# Altium schematic sheet stm32.SchDoc
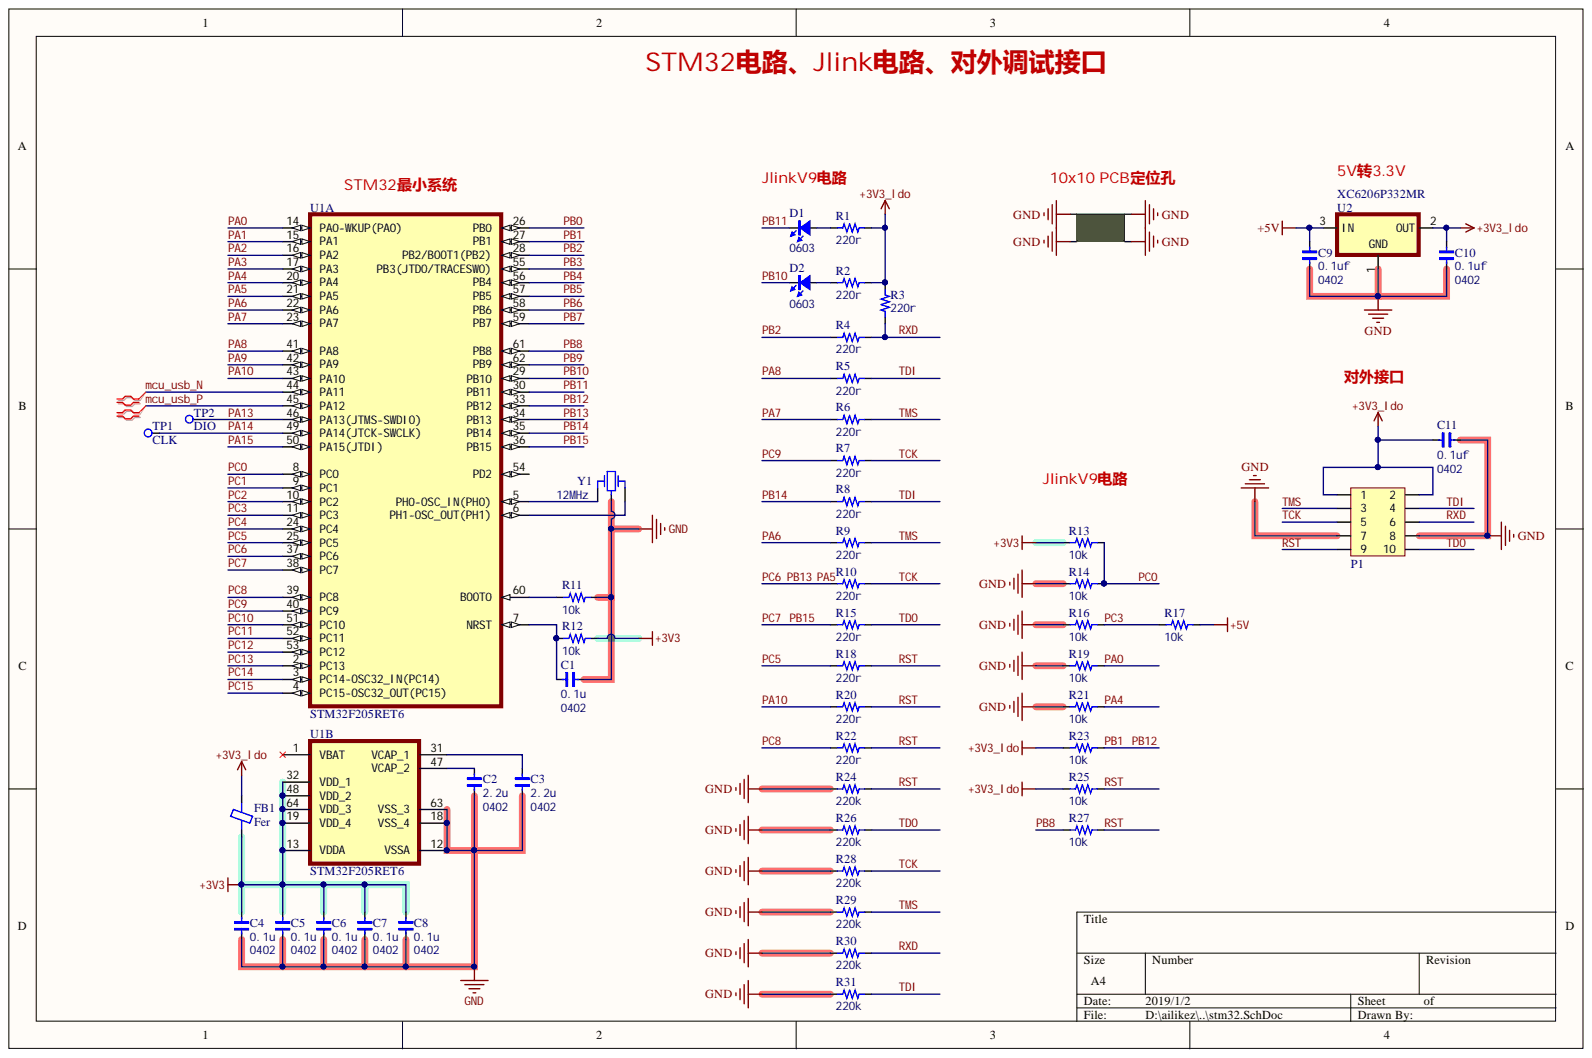
[diagram p0: stm32.SchDoc]

## Parts
C1  value 0.1u  Type: 0402  [CAP_0402]  (hidden comment: C0402)
C2  value 2.2u  Type: 0402  [CAP_0402]  (hidden comment: C0402)
C3  value 2.2u  Type: 0402  [CAP_0402]  (hidden comment: C0402)
C4  value 0.1u  Type: 0402  [CAP_0402]  (hidden comment: C0402)
C5  value 0.1u  Type: 0402  [CAP_0402]  (hidden comment: C0402)
C6  value 0.1u  Type: 0402  [CAP_0402]  (hidden comment: C0402)
C7  value 0.1u  Type: 0402  [CAP_0402]  (hidden comment: C0402)
C8  value 0.1u  Type: 0402  [CAP_0402]  (hidden comment: C0402)
C9  value 0.1uf  Type: 0402  [CAP_0402]  (hidden comment: C0402)
C10  value 0.1uf  Type: 0402  [CAP_0402]  (hidden comment: C0402)
C11  value 0.1uf  Type: 0402  [CAP_0402]  (hidden comment: C0402)
D1  Type: 0603  [LED_0603]  (hidden comment: color?)
D2  Type: 0603  [LED_0603]  (hidden comment: color?)
FB1  Fer  [FER 0603/1608]
P1  HDR2x5_2.54  [HDR2X5_2.54_180H]  (value not drawn)
PCB  PCB_100x100  [PCB_100x100]  (value not drawn)
R1  220r  [RES_0402]
R2  220r  [RES_0402]
R3  220r  [RES_0402]
R4  220r  [RES_0402]
R5  220r  [RES_0402]
R6  220r  [RES_0402]
R7  220r  [RES_0402]
R8  220r  [RES_0402]
R9  220r  [RES_0402]
R10  220r  [RES_0402]
R11  10k  [RES_0402]
R12  10k  [RES_0402]
R13  10k  [RES_0402]
R14  10k  [RES_0402]
R15  220r  [RES_0402]
R16  10k  [RES_0402]
R17  10k  [RES_0402]
R18  220r  [RES_0402]
R19  10k  [RES_0402]
R20  220r  [RES_0402]
R21  10k  [RES_0402]
R22  220r  [RES_0402]
R23  10k  [RES_0402]
R24  220k  [RES_0402]
R25  10k  [RES_0402]
R26  220k  [RES_0402]
R27  10k  [RES_0402]
R28  220k  [RES_0402]
R29  220k  [RES_0402]
R30  220k  [RES_0402]
R31  220k  [RES_0402]
TP1  CLK  [TP Circle]
TP2  DIO  [TP Circle]
U1  STM32F205RET6  [_LQFP_P0.5_64]  (parts A,B)
U2  XC6206P332MR  [_SOT23-3]
Y1  12MHz  [MURATA CSTCE]

## Nets
+3V3: C4.2 C5.2 C6.2 C7.2 C8.2 FB1.1 R12.2 R13.1 U1.13(VDDA) U1.19(VDD_4) U1.32(VDD_1) U1.48(VDD_2) U1.64(VDD_3)
+3V3_ldo: C10.2 C11.2 FB1.2 P1.1 P1.2 R1.2 R2.2 R3.2 R23.1 R25.1 U2.2
+5V: C9.2 R17.2 U2.3
GND: C1.2 C2.1 C3.1 C4.1 C5.1 C6.1 C7.1 C8.1 C9.1 C10.1 C11.1 P1.7 P1.8 PCB.1 PCB.2 PCB.3 PCB.4 R11.2 R14.1 R16.1 R19.1 R21.1 R24.1 R26.1 R28.1 R29.1 R30.1 R31.1 U1.12(VSSA) U1.18(VSS_4) U1.63(VSS_3) U2.1 Y1.2
mcu_usb_N: U1.44(PA11)
mcu_usb_P: U1.45(PA12)
PA0: R19.2 U1.14(PA0-WKUP(PA0))
PA1: U1.15(PA1)
PA2: U1.16(PA2)
PA3: U1.17(PA3)
PA4: R21.2 U1.20(PA4)
PA5: R10.1 U1.21(PA5) U1.34(PB13) U1.37(PC6)
PA6: R9.1 U1.22(PA6)
PA7: R6.1 U1.23(PA7)
PA8: R5.1 U1.41(PA8)
PA9: U1.42(PA9)
PA10: R20.1 U1.43(PA10)
PA13: TP2.1 U1.46(PA13(JTMS-SWDIO))
PA14: TP1.1 U1.49(PA14(JTCK-SWCLK))
PA15: U1.50(PA15(JTDI))
PB0: U1.26(PB0)
PB1: R23.2 U1.27(PB1) U1.33(PB12)
PB2: R4.1 U1.28(PB2/BOOT1(PB2))
PB3: U1.55(PB3(JTDO/TRACESWO))
PB4: U1.56(PB4)
PB5: U1.57(PB5)
PB6: U1.58(PB6)
PB7: U1.59(PB7)
PB8: R27.1 U1.61(PB8)
PB9: U1.62(PB9)
PB10: D2.2 U1.29(PB10)
PB11: D1.2 U1.30(PB11)
PB14: R8.1 U1.35(PB14)
PB15: R15.1 U1.36(PB15) U1.38(PC7)
PC0: R13.2 R14.2 U1.8(PC0)
PC1: U1.9(PC1)
PC2: U1.10(PC2)
PC3: R16.2 R17.1 U1.11(PC3)
PC4: U1.24(PC4)
PC5: R18.1 U1.25(PC5)
PC8: R22.1 U1.39(PC8)
PC9: R7.1 U1.40(PC9)
PC10: U1.51(PC10)
PC11: U1.52(PC11)
PC12: U1.53(PC12)
PC13: U1.2(PC13)
PC14: U1.3(PC14-OSC32_IN(PC14))
PC15: U1.4(PC15-OSC32_OUT(PC15))
RST: P1.9 R18.2 R20.2 R22.2 R24.2 R25.2 R27.2
RXD: P1.6 R3.1 R4.2 R30.2
TCK: P1.5 R7.2 R10.2 R28.2
TDI: P1.4 R5.2 R8.2 R31.2
TDO: P1.10 R15.2 R26.2
TMS: P1.3 R6.2 R9.2 R29.2
NetC1_1: C1.1 R12.1 U1.7(NRST)
NetC2_2: C2.2 U1.47(VCAP_2)
NetC3_2: C3.2 U1.31(VCAP_1)
NetD1_1: D1.1 R1.1
NetD2_1: D2.1 R2.1
NetR11_1: R11.1 U1.60(BOOT0)
NetU1_5: U1.5(PH0-OSC_IN(PH0)) Y1.1
NetU1_6: U1.6(PH1-OSC_OUT(PH1)) Y1.3

Not connected: U1 1(VBAT) 54(PD2)

## Library data of the parts
C1-C11 = Cap_0402: Capacitor; Specification: X7R 50V; Component Kind: Standard; Library Name: adlib.IntLib
D1 D2 = LED_0603: LED; Component Kind: Standard; Value: color?; Library Name: adlib.IntLib
FB1 = Fer: Ferrite Bead; Component Kind: Standard; Library Name: adlib.IntLib
P1 = HDR2x5_2.54: Header; Component Kind: Standard; Library Name: adlib.IntLib
PCB = PCB_100x100: PCB; Component Kind: Standard; Library Name: adlib.IntLib
R1-R31 = Res: SMT Resistor; Component Kind: Standard; Library Name: adlib.IntLib
  R1-R10 R15 R18 R20 R22: Value: 220r
  R11-R14 R16 R17 R19 R21 R23 R25 R27: Value: 10k
  R24 R26 R28-R31: Value: 220k
TP1 TP2 = Test Point: Test; Component Kind: Standard; Library Name: adlib.IntLib
U1 = STM32F205RET6: ARM Cortex-M3 32-bit MCU, 512 KB Flash, 128 KB Internal RAM, 51 I/Os, 64-pin LQFP, -40 to 85 degC, Tray; D/A Converter: 2x12-bit (2 Channel); FLASH Size (Prog) (kB): 512; I/Os (High Current): 51; Internal RAM Size (kB): 128; Operating Frequency(F) (Processor speed) (MHz): 120; Supply Voltage(Vcc) min (V): 1.8; Component Kind: Standard; ComponentLink1Description: Manufacturer URL; ComponentLink1URL: http://www.st.com/web/en/home.html; ComponentLink2Description: Datasheet; ComponentLink2URL: http://www.st.com/st-web-ui/static/active/en/resource/technical/document/datasheet/CD00237391.pdf; ComponentLink3Description: Package Specification; ComponentLink3URL: http://www.st.com/st-web-ui/static/active/en/resource/technical/document/datasheet/DM00037051.pdf; Core: ARM Cortex-M3; DatasheetVersion: Rev. 9; Mounting Technology: Surface Mount; PackageDescription: 64-Pin Low Profile Quad Flat Package, 10 x 10 mm Body, 0.5 mm Pitch; PackageReference: LQFP64; PackageVersion: Rev. 4, 06/2013; Packing: Tray; PartNumber: STM32F205RET6; Supplier 1: Digi-Key; Supplier 2: Farnell; Supplier 3: Newark; Supplier 4: Mouser; Supplier Part Number 1: 497-11155-ND; Supplier Part Number 2: 2060897; Supplier Part Number 3: 47T9329; Supplier Part Number 4: 511-STM32F205RET6; Library Name: adlib.IntLib
U2 = XC6206P332MR: Regulator LDO; Component Kind: Standard; Library Name: adlib.IntLib
Y1 = XTAL_MURATA_3P: Crystal Oscillator With Caps Inside; Component Kind: Standard; Value: 12MHz; Library Name: adlib.IntLib
